# Altium schematic sheet Sheet1.SchDoc
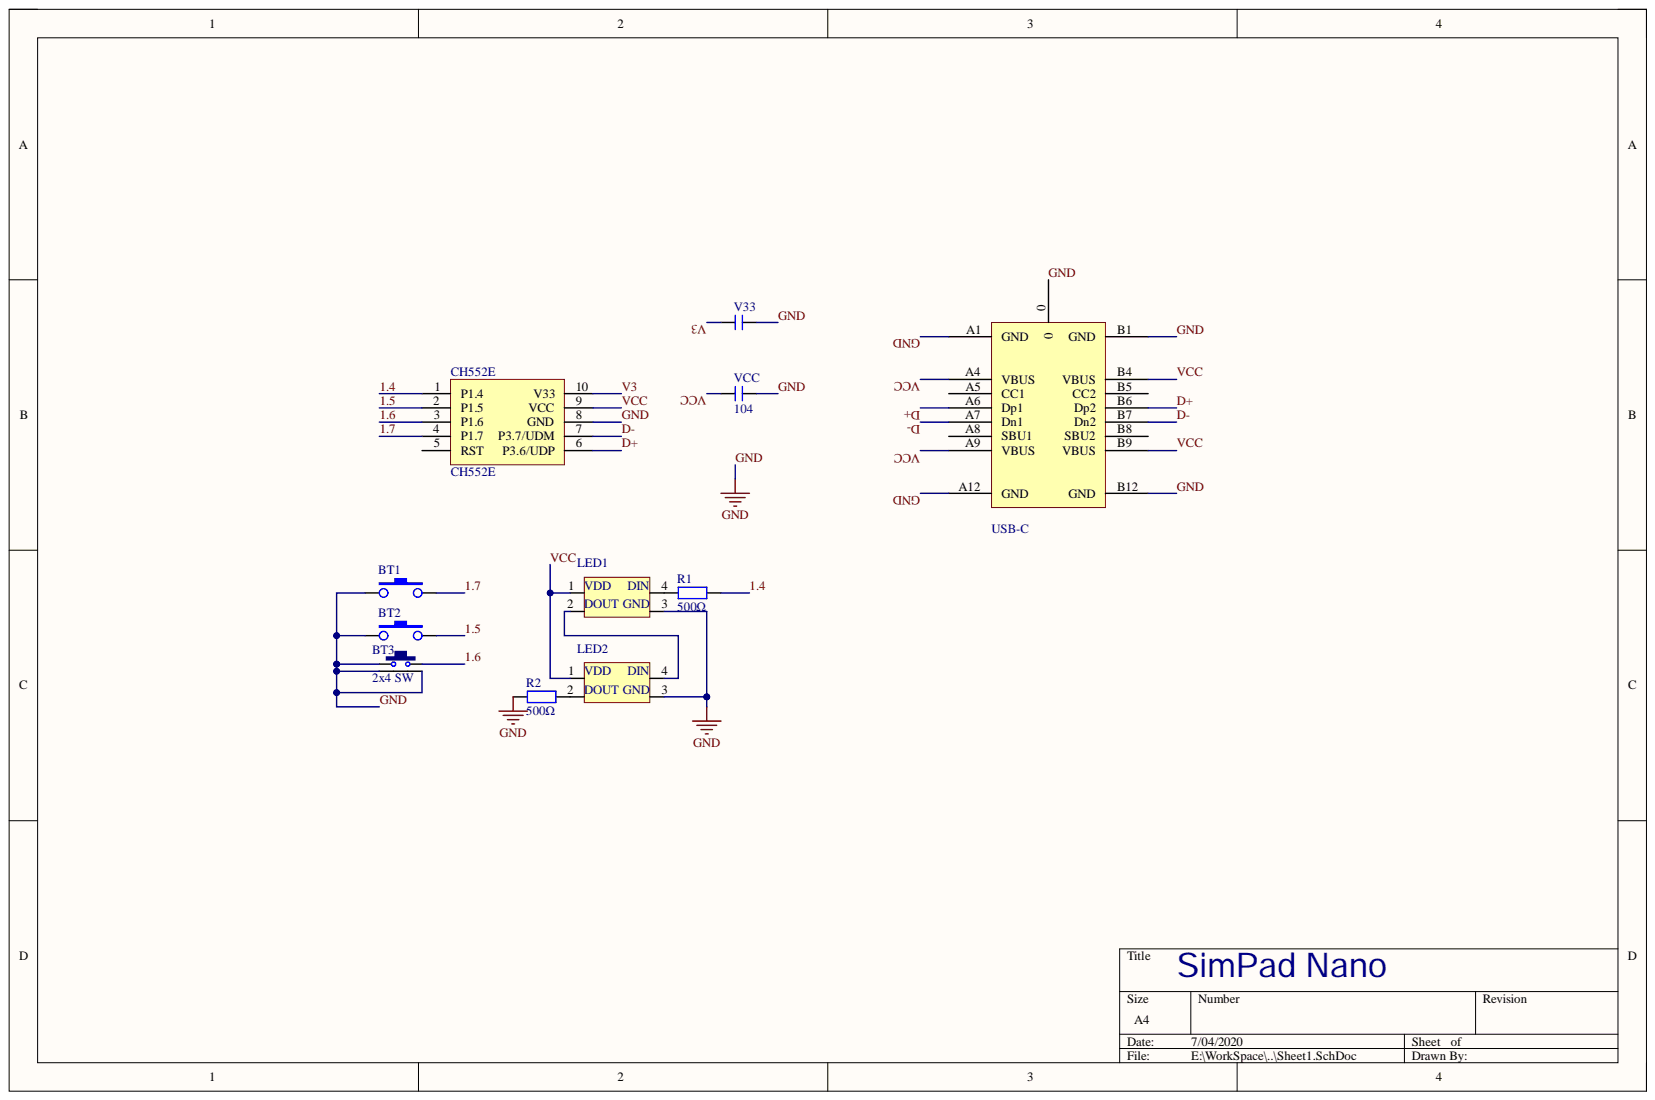
[diagram p0: Sheet1.SchDoc]

## Parts
BT1  MX_SW  [MX_SW-4 -3F]  (value not drawn)
BT2  MX_SW  [MX_SW-4 -3F]  (value not drawn)
BT3  2x4 SW  [侧按 带柱 2*4*3.5]
CH552E  CH552E  [MSOP10_L]
LED1  WS2812-1  [WS2812-3528反贴]  (value not drawn)
LED2  WS2812-2  [WS2812-3528反贴]  (value not drawn)
R1  500Ω  [R 0402_L]
R2  500Ω  [R 0402_L]
USB-C  USB-C  [USB-typeC-16P-SMT]
V33  104  [C 0402_L]  (value not drawn)
VCC  104  [C 0402_L]

## Nets
1.4: CH552E.1(P1.4) R1.2
1.5: BT2.2 CH552E.2(P1.5)
1.6: BT3.2 CH552E.3(P1.6)
1.7: BT1.2 CH552E.4(P1.7)
D+: CH552E.6(P3.6/UDP) USB-C.A6(Dp1) USB-C.B6(Dp2)
D-: CH552E.7(P3.7/UDM) USB-C.A7(Dn1) USB-C.B7(Dn2)
GND: BT1.1 BT2.1 BT3.1 BT3.3 BT3.4 CH552E.8(GND) LED1.3 LED2.3 R2.1 USB-C.0 USB-C.A1(GND) USB-C.A12(GND) USB-C.B1(GND) USB-C.B12(GND) V33.2 VCC.2
V3: CH552E.10(V33) V33.1
VCC: CH552E.9(VCC) LED1.1 LED2.1 USB-C.A4(VBUS) USB-C.A9(VBUS) USB-C.B4(VBUS) USB-C.B9(VBUS) VCC.1
NetLED1_2: LED1.2 LED2.4
NetLED1_4: LED1.4 R1.1
NetLED2_2: LED2.2 R2.2

Not connected: CH552E 5(RST); USB-C A5(CC1) A8(SBU1) B5(CC2) B8(SBU2)

## Library data of the parts
BT1 BT2 = MX_SW-4: with Fixation pins  1-Pole; Component Kind: Standard; Library Name: KeyBoard.SchLib
BT3 = SMT 2*4*3.5 侧按: Component Kind: Standard
CH552E = BS814A: 4键电容触摸按键芯片; 版权归属: 源创客; BOM名称: 4键电容触摸按键芯片; Component Kind: Standard; Library Name: 1.1   - IC芯片.SchLib; 学习资源: www.ooocke.com
LED1 LED2 = WS2812-3528: Component Kind: Standard
R1 R2 = R: 贴片电阻; 版权归属: 源创客; BOM名称: 贴片电阻; Component Kind: Standard; Library Name: 3.1   - 电阻-电容-电感.SchLib; 学习资源: www.ooocke.com
USB-C = USB-C/16P-SMT: USB-C型母接口; 版权归属: 手柄君; BOM名称: USB-C型母接口; Component Kind: Standard; Library Name: 4.1   - 接口类.SchLib; 学习资源: www.bysb.net
V33 VCC = C: 无极性贴片电容; 版权归属: 源创客; BOM名称: 无极性贴片电容; Component Kind: Standard; Library Name: 3.1   - 电阻-电容-电感.SchLib; 学习资源: www.ooocke.com
